# Altium schematic sheet 03-MOTEUR_BUZ_LED.SchDoc(03-MOTEUR_BUZ_LED)
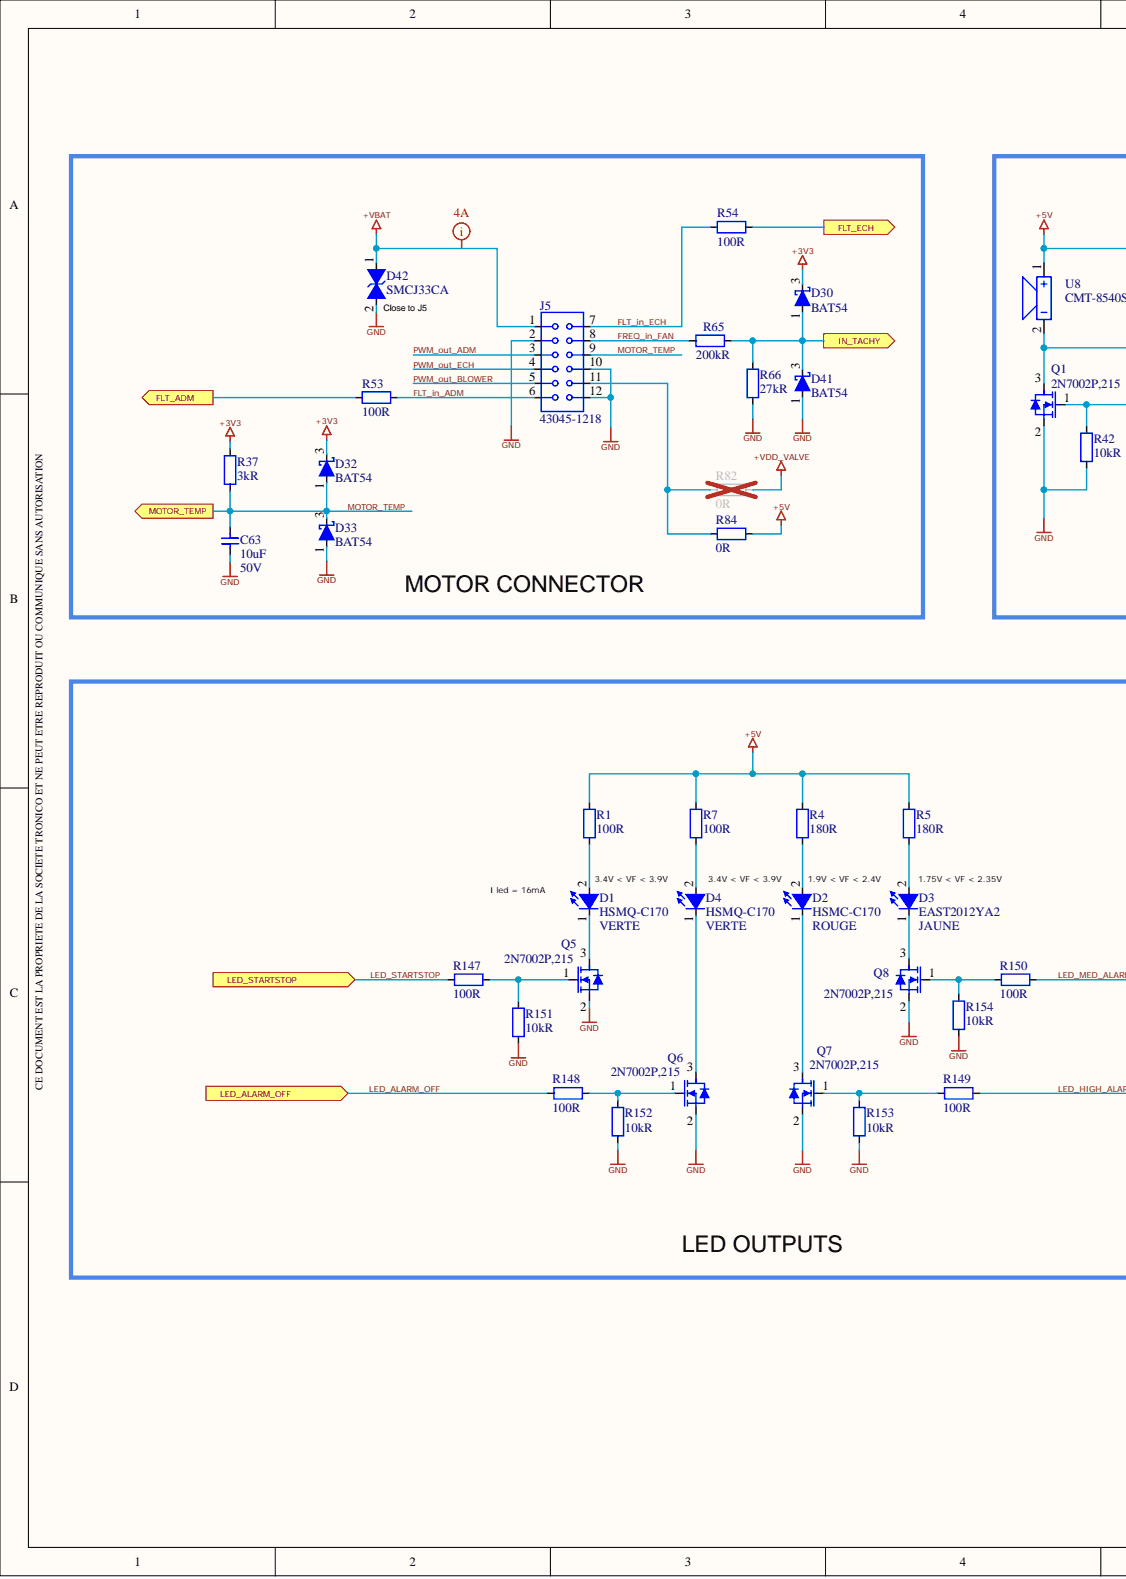
[diagram p0: 03-MOTEUR_BUZ_LED.SchDoc, left]
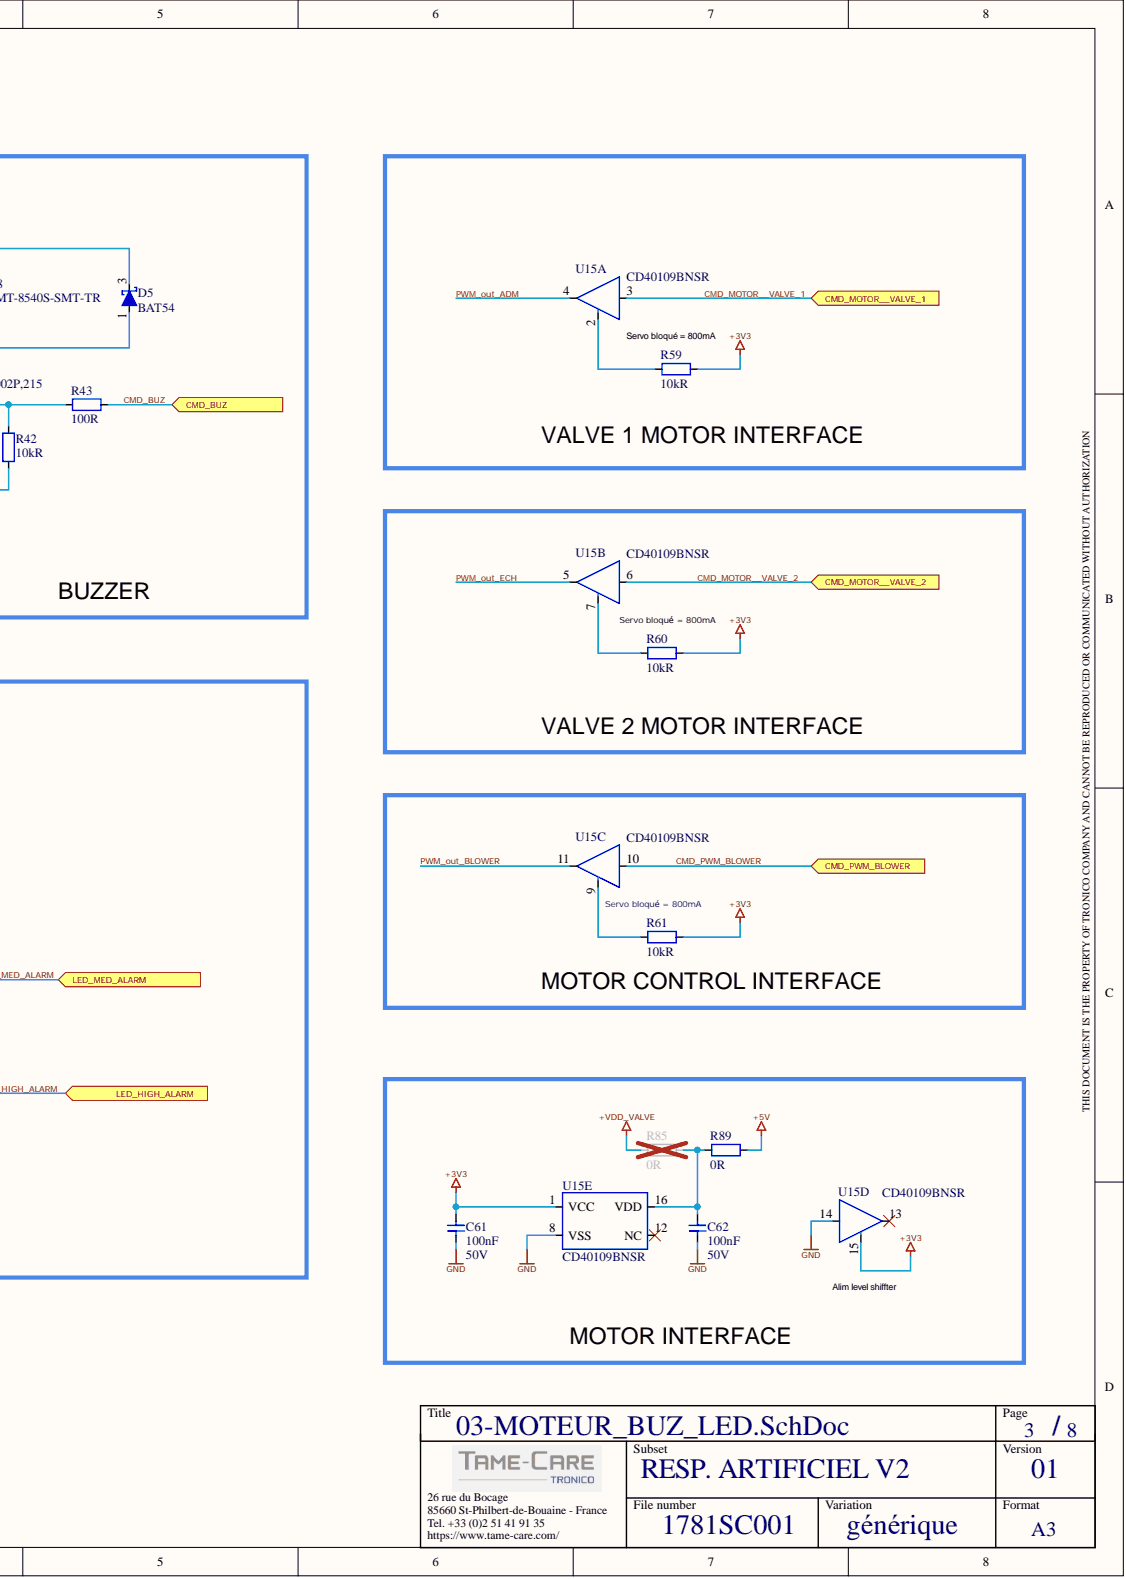
[diagram p1: 03-MOTEUR_BUZ_LED.SchDoc, right]

## Parts
C61  100nF  Parameter 1: 50V  [CAPC_0603X094_T]
C62  100nF  Parameter 1: 50V  [CAPC_0603X094_T]
C63  10uF  Parameter 1: 50V  [CAPC_1206X160_T]
D1  HSMQ-C170  Parameter 1: VERTE  CHAMP7: 3.4V  [LEDC_0805X080_GREEN_T]
D2  HSMC-C170  Parameter 1: ROUGE  [LEDC_0805X080_RED_T]
D3  EAST2012YA2  Parameter 1: JAUNE  [LEDC_0805X100_YELLOW_T]
D4  HSMQ-C170  Parameter 1: VERTE  CHAMP7: 3.4V  [LEDC_0805X080_GREEN_T]
D5  BAT54  [SOT23_T]
D30  BAT54  [SOT23_T]
D32  BAT54  [SOT23_T]
D33  BAT54  [SOT23_T]
D41  BAT54  [SOT23_T]
D42  SMCJ33CA  [DIOC_SMC_DO-214AB_BIDIR_B]
J5  43045-1218  [CNT_MOLEX_43045-1218_B]
Q1  2N7002P,215  [SOT23_T]
Q5  2N7002P,215  [SOT23_T]
Q6  2N7002P,215  [SOT23_T]
Q7  2N7002P,215  [SOT23_T]
Q8  2N7002P,215  [SOT23_T]
R1  100R  [RESC_0603X045_T]
R4  180R  [RESC_0603X045_T]
R5  180R  [RESC_0603X045_T]
R7  100R  [RESC_0603X045_T]
R37  3kR  [RESC_0603X045_T]
R42  10kR  [RESC_0603X045_T]
R43  100R  [RESC_0603X045_T]
R53  100R  [RESC_0603X045_T]
R54  100R  [RESC_0603X045_T]
R59  10kR  [RESC_0603X045_T]
R60  10kR  [RESC_0603X045_T]
R61  10kR  [RESC_0603X045_T]
R65  200kR  [RESC_0603X045_T]
R66  27kR  [RESC_0603X045_T]
R82  0R  [RESC_1206X055_T]
R84  0R  [RESC_1206X055_T]
R85  0R  [RESC_1206X055_T]
R89  0R  [RESC_1206X055_T]
R147  100R  [RESC_0603X045_T]
R148  100R  [RESC_0603X045_T]
R149  100R  [RESC_0603X045_T]
R150  100R  [RESC_0603X045_T]
R151  10kR  [RESC_0603X045_T]
R152  10kR  [RESC_0603X045_T]
R153  10kR  [RESC_0603X045_T]
R154  10kR  [RESC_0603X045_T]
U8  CMT-8540S-SMT-TR  [BUZZER_CUI-CMT-8540S-SMT-TR_B]
U15  CD40109BNSR  [SO16_10.2x5.3_1.27]  (parts A,B,C,D,E)

## Ports
CMD_BUZ, CMD_MOTOR__VALVE_1, CMD_MOTOR__VALVE_2, CMD_PWM_BLOWER, FLT_ADM, FLT_ECH, IN_TACHY, LED_ALARM_OFF, LED_HIGH_ALARM, LED_MED_ALARM, LED_STARTSTOP, MOTOR_TEMP

## Nets
+3V3: C61.2 D30.3 D32.3 R37.1 R59.2 R60.2 R61.2 U15.1(VCC) U15.15
+5V: D5.3 R1.1 R4.1 R5.1 R7.1 R84.1 R89.1 U8.1
+VBAT: D42.1 J5.1
+VDD_VALVE: R82.1 R85.2
GND: C61.1 C62.1 C63.1 D33.1 D41.1 D42.2 J5.2 J5.10 J5.12 Q1.2 Q5.2 Q6.2 Q7.2 Q8.2 R42.2 R66.2 R151.2 R152.2 R153.2 R154.2 U15.8(VSS) U15.14
CMD_BUZ: R43.1 ; port CMD_BUZ
CMD_MOTOR__VALVE_1: U15.3 ; port CMD_MOTOR__VALVE_1
CMD_MOTOR__VALVE_2: U15.6 ; port CMD_MOTOR__VALVE_2
CMD_PWM_BLOWER: U15.10 ; port CMD_PWM_BLOWER
FLT_ADM: R53.2 ; port FLT_ADM
FLT_ECH: R54.1 ; port FLT_ECH
FLT_in_ADM: J5.6 R53.1
FLT_in_ECH: J5.7 R54.2
FREQ_in_FAN: J5.8 R65.2
IN_TACHY: D30.1 D41.3 R65.1 R66.1 ; port IN_TACHY
LED_ALARM_OFF: R148.2 ; port LED_ALARM_OFF
LED_HIGH_ALARM: R149.1 ; port LED_HIGH_ALARM
LED_MED_ALARM: R150.1 ; port LED_MED_ALARM
LED_STARTSTOP: R147.2 ; port LED_STARTSTOP
MOTOR_TEMP: C63.2 D32.1 D33.3 J5.9 R37.2 ; port MOTOR_TEMP
PWM_out_ADM: J5.3 U15.4
PWM_out_BLOWER: J5.5 U15.11
PWM_out_ECH: J5.4 U15.5
NetC62_2: C62.2 R85.1 R89.2 U15.16(VDD)
NetD1_1: D1.1 Q5.3
NetD1_2: D1.2 R1.2
NetD2_1: D2.1 Q7.3
NetD2_2: D2.2 R4.2
NetD3_1: D3.1 Q8.3
NetD3_2: D3.2 R5.2
NetD4_1: D4.1 Q6.3
NetD4_2: D4.2 R7.2
NetD5_1: D5.1 Q1.3 U8.2
NetJ5_11: J5.11 R82.2 R84.2
NetQ1_1: Q1.1 R42.1 R43.2
NetQ5_1: Q5.1 R147.1 R151.1
NetQ6_1: Q6.1 R148.1 R152.1
NetQ7_1: Q7.1 R149.2 R153.1
NetQ8_1: Q8.1 R150.2 R154.1
NetR59_1: R59.1 U15.2
NetR60_1: R60.1 U15.7
NetR61_1: R61.1 U15.9

Not connected: U15 12(NC) 13

## Library data of the parts
C61 C62 = 23U00036: COND_CERAM CMS 0603 X7R 100nF 50V 10%; REV_NOTE: Maj Champs Techniques; REV_AUTHOR: nlelievre; REF_FAB: 06035C104KAT2A; LEVEL: 1; FAMILLE: CAPACITIFS; FAB: AVX; Database Table Name: v_bt_all; CHAMP9: -55°C/+125°C; CHAMP2: 0603; SS_FAMILLE: COND_CERAM; Simulation: CAP; Signal Integrity: Cap; REV_DATE: 16/10/2019 13:20:57; Library Name: SVNDatabase_BaseTechnique.SVNDbLib; ID_RnD: 23U00036; HelpURL: http://Bt_rnd/ds/02_capacitif/AVX/AVX_cx7r.pdf; ComponentLink2Description: Manufacturer Website; ComponentLink1Description: Manufacturer Datasheet; Component Kind: Standard; CHAMP7: 10%; CHAMP6: 50V; CHAMP5: 100nF; CHAMP3: X7R; CHAMP1: CMS; ACTIF: ACTIF
C63 = 23U00488: COND_CERAM CMS 1206 X5R 10uF 50V 10%; REV_NOTE: Migration vers t_bt; REV_AUTHOR: lclavier; REF_FAB: GRM31CR61H106KA12L; LEVEL: 1; FAMILLE: CAPACITIFS; FAB: MURATA; Database Table Name: v_bt_all; CHAMP9: -55°C/+85°C; CHAMP2: 1206; SS_FAMILLE: COND_CERAM; Simulation: CAP; Signal Integrity: Cap; REV_DATE: 14/04/2020 16:23:14; ID_RnD: 23U00488; HelpURL: http://bt_rnd/ds/02_capacitif/murata/GRM31CR61H106KA12L.pdf; ComponentLink1URL: https://www.murata.com/en-us/products/productdetail?partno=GRM31CR61H106KA12%23; Component Kind: Standard; CHAMP7: 10%; CHAMP6: 50V; CHAMP5: 10uF; CHAMP3: X5R; CHAMP1: CMS; ACTIF: ACTIF; Library Name: SVNDatabase_BaseTechnique.SVNDbLib
D1 D4 = E1U00030: DIODE_LED VERTE CMS 0805 520 78mW 3.4V 20mA; REV_NOTE: Migration vers t_bt; REV_AUTHOR: lclavier; LEVEL: 1; FAMILLE: OPTOELECTRONIQUE; FAB: AVAGO; Database Table Name: v_bt_all; CHAMP10: -40°C/+85°C; CHAMP5: 520; CHAMP4: 0805; SS_FAMILLE: DIODE_LED; REV_DATE: 02/05/2020 13:21:23; REF_FAB: HSMQ-C170; ID_RnD: E1U00030; HelpURL: http://bt_rnd/ds/15_opto/AVAGO/HSMQ-C1xx-HSMR-C1xx.pdf; ComponentLink2Description: Manufacturer Website; ComponentLink1Description: Manufacturer Datasheet; Component Kind: Standard; CHAMP9: -40°C/+85°C; CHAMP8: 20mA; CHAMP6: 78mW; CHAMP3: CMS; CHAMP1: VERTE; ACTIF: ACTIF; Library Name: SVNDatabase_BaseTechnique.SVNDbLib
D2 = E1U00031: DIODE_LED ROUGE CMS 0805 637 60mW 2.4V 25mA; REV_NOTE: Migration vers t_bt; REV_AUTHOR: lclavier; LEVEL: 1; FAMILLE: OPTOELECTRONIQUE; FAB: AVAGO; Database Table Name: v_bt_all; CHAMP10: -40°C/+85°C; CHAMP5: 637; CHAMP4: 0805; SS_FAMILLE: DIODE_LED; REV_DATE: 02/05/2020 13:21:25; REF_FAB: HSMC-C170; ID_RnD: E1U00031; HelpURL: http://bt_rnd/ds/15_opto/AVAGO/HSMx-C110-C170-C190-C191-C150.pdf; ComponentLink2Description: Manufacturer Website; ComponentLink1Description: Manufacturer Datasheet; Component Kind: Standard; CHAMP9: -40°C/+85°C; CHAMP8: 25mA; CHAMP7: 2.4V; CHAMP6: 60mW; CHAMP3: CMS; CHAMP1: ROUGE; ACTIF: ACTIF; Library Name: SVNDatabase_BaseTechnique.SVNDbLib
D3 = E1U00032: DIODE_LED JAUNE CMS 0805 591 85mcd 2.05V 20mA; REV_NOTE: Migration vers t_bt; REV_AUTHOR: lclavier; LEVEL: 1; FAMILLE: OPTOELECTRONIQUE; FAB: Everlight Electronics; Database Table Name: v_bt_all; CHAMP10: -40°C/+90°C; CHAMP9: -40°C/+85°C; CHAMP5: 591; CHAMP4: 0805; SS_FAMILLE: DIODE_LED; REV_DATE: 02/05/2020 13:21:28; REF_FAB: EAST2012YA2; ID_RnD: E1U00032; HelpURL: http://bt_rnd/ds/15_opto/EVERLIGHT/EAST2012YA2-708101.pdf; ComponentLink2Description: Manufacturer Website; ComponentLink1Description: Manufacturer Datasheet; Component Kind: Standard; CHAMP8: 20mA; CHAMP7: 2.05V; CHAMP6: 85mcd; CHAMP3: CMS; CHAMP1: JAUNE; ACTIF: ACTIF; Library Name: SVNDatabase_BaseTechnique.SVNDbLib
D5 D30 D32 D33 D41 = 33U00013: DIODE_DE_SIGNAL CMS SOT23  30V 200mA -55°C/+150°C -55°C/+150°C; REV_NOTE: MàJ footprint_path et footprint_ref; REV_AUTHOR: llannuzel; QUALIF: None; LEVEL: 1; FAMILLE: DIODES; FAB: FAIRCHILD; Database Table Name: v_bt_all; SS_FAMILLE: DIODE_DE_SIGNAL; Simulation: SKYDIODE; Signal Integrity: D Schottky; REV_DATE: 13/12/2019 10:03:18; REF_FAB: BAT54; ID_RnD: 33U00013; HelpURL: http://bt_rnd/ds/03_diode/FAIRCHILD/BAT54_x.pdf; ComponentLink2Description: Manufacturer Website; ComponentLink1Description: Manufacturer Datasheet; Component Kind: Standard; CHAMP10: -55°C/+150°C; CHAMP9: -55°C/+150°C; CHAMP6: 200mA; CHAMP5: 30V; CHAMP2: SOT23; CHAMP1: CMS; ACTIF: ACTIF; Library Name: SVNDatabase_BaseTechnique.SVNDbLib
D42 = 36U00007: DIODE_TRANSIL CMS DO214AB  BI 33V 1500W; REV_NOTE: MaJ Champs URL; REV_AUTHOR: llannuzel; LEVEL: 1; FAMILLE: DIODES; FAB: FAIRCHILD; Database Table Name: v_bt_all; CHAMP10: -55°C/+150°C; CHAMP2: DO-214AB; SS_FAMILLE: DIODE_TRANSIL; REV_DATE: 02/05/2020 17:44:04; REF_FAB: SMCJ33CA; ID_RnD: 36U00007; HelpURL: http://bt_rnd/ds/03_diode/FAIRCHILD/SMCJ_serie.pdf; ComponentLink2Description: None; ComponentLink1Description: None; Component Kind: Standard; CHAMP9: -55°C/+150°C; CHAMP6: 1500W; CHAMP5: 33V; CHAMP4: BI; CHAMP1: CMS; ACTIF: ACTIF; Library Name: SVNDatabase_BaseTechnique.SVNDbLib
J5 = A7U00305: CONNECT MICRO-FIT CMS 2x6PTS EMBASE RECT MALE DROIT; REV_NOTE: MaJ Champs Techniques; REV_AUTHOR: lclavier; QUALIF: None; LEVEL: 1; FAMILLE: CONNECTIQUE; FAB: MOLEX; Database Table Name: v_bt_all; CHAMP10: -40°C/+105°C; SS_FAMILLE: CONNECT; REV_DATE: 29/04/2020 19:11:28; REF_FAB: 43045-1218; Library Name: SVNDatabase_BaseTechnique.SVNDbLib; ID_RnD: A7U00305; HelpURL: http://bt_rnd/ds/10_connectique/MOLEX/0430451218_PCB_HEADERS.pdf; ComponentLink2Description: Manufacturer Website; ComponentLink1Description: Manufacturer Datasheet; Component Kind: Standard; CHAMP9: -40°C/+105°C; CHAMP8: DROIT; CHAMP7: MALE; CHAMP6: RECT; CHAMP5: EMBASE; CHAMP4: 2x6PTS; CHAMP3: CMS; CHAMP1: MICRO-FIT; ACTIF: ACTIF
Q1 Q5-Q8 = 92U00012: TRANSISTOR_MOS CMS SOT23 CANAL N 60V 0.36A -55°C/+150°C; Valide / Non Valide: Ok; REV_NOTE: Maj Library Ref; REV_AUTHOR: jcaille; LEVEL: 1; FAMILLE: TRANSISTORS; FAB: NXP; Database Table Name: v_bt_all; CHAMP10: -65°C/+150°C; CHAMP5: CANAL N; SS_FAMILLE: TRANSISTOR_MOS; REV_DATE: 22/01/2020 14:26:53; REF_FAB: 2N7002P,215; ID_RnD: 92U00012; HelpURL: http://bt_rnd/ds/09_transistor/NXP/NXP_2N7002P.pdf; ComponentLink2Description: Manufacturer Website; ComponentLink1Description: Manufacturer Datasheet; Component Kind: Standard; CHAMP9: -55°C/+150°C; CHAMP7: 0.36A; CHAMP6: 60V; CHAMP2: SOT23; CHAMP1: CMS; ACTIF: ACTIF; Library Name: SVNDatabase_BaseTechnique.SVNDbLib
R1 R7 R43 R53 R54 R147-R150 = 11U00071: REST CMS 0603 100PPM 100R 1/10W 1% -55°C/+155°C; Valide / Non Valide: OK; REV_NOTE: MaJ description; REV_AUTHOR: jrenvoise; REF_FAB: RC0603FR-07100RL; LEVEL: 1; FAMILLE: RESISTIFS; FAB: YAGEO; Database Table Name: v_bt_all; CHAMP2: 0603; SS_FAMILLE: REST; Simulation: RESISTOR; Signal Integrity: Res; REV_DATE: 12/09/2018 10:54:26; ID_RnD: 11U00071; HelpURL: http://bt_rnd/ds/01_resistif/yageo/RC-L_Series.pdf; ComponentLink2Description: Manufacturer Website; ComponentLink1Description: Manufacturer Datasheet; Component Kind: Standard; CHAMP9: -55°C/+155°C; CHAMP7: 1%; CHAMP6: 1/10W; CHAMP5: 100R; CHAMP3: 100PPM; CHAMP1: CMS; ACTIF: ACTIF; Library Name: SVNDatabase_BaseTechnique.SVNDbLib
R4 R5 = 11U01011: REST CMS 0603 200PPM 180R 1/10W 1% 75V; Valide / Non Valide: OK; REV_NOTE: Migration vers t_bt; REV_AUTHOR: jcaille; REF_FAB: CRCW0603180RJNEA; LEVEL: 1; FAMILLE: RESISTIFS; FAB: VISHAY; Database Table Name: v_bt_all; CHAMP9: -55°C/+155°C; CHAMP2: 0603; SS_FAMILLE: REST; Simulation: RESISTOR; Signal Integrity: Res; REV_DATE: 17/04/2020 11:05:26; ID_RnD: 11U01011; HelpURL: http://bt_rnd/ds/01_resistif/Vishay/dcrcwe3.pdf; ComponentLink2Description: Manufacturer Website; ComponentLink1Description: Manufacturer Datasheet; Component Kind: Standard; CHAMP8: 75V; CHAMP7: 1%; CHAMP6: 1/10W; CHAMP5: 180R; CHAMP3: 200PPM; CHAMP1: CMS; ACTIF: ACTIF; Library Name: SVNDatabase_BaseTechnique.SVNDbLib
R37 = 11U00977: REST CMS 0603 100PPM 3kR 1/10W 1% -55°C/+155°C; Valide / Non Valide: OK; REV_NOTE: MAJ DESCRIPTION; REV_AUTHOR: lclavier; REF_FAB: RC0603FR-073KL; QUALIF: None; LEVEL: 1; FAMILLE: RESISTIFS; FAB: YAGEO; Database Table Name: v_bt_all; CHAMP2: 0603; SS_FAMILLE: REST; Simulation: RESISTOR; Signal Integrity: Res; REV_DATE: 07/02/2020 15:40:42; ID_RnD: 11U00977; HelpURL: http://bt_rnd/ds/01_resistif/yageo/RC-L_Series.pdf; ComponentLink2Description: Manufacturer Website; ComponentLink1Description: Manufacturer Datasheet; Component Kind: Standard; CHAMP9: -55°C/+155°C; CHAMP7: 1%; CHAMP6: 1/10W; CHAMP5: 3kR; CHAMP3: 100PPM; CHAMP1: CMS; ACTIF: ACTIF; Library Name: SVNDatabase_BaseTechnique.SVNDbLib
R42 R59-R61 R151-R154 = 11U00108: REST CMS 0603 100PPM 10kR 1/10W 1% -55°C/+155°C; Valide / Non Valide: OK; REV_NOTE: MaJ description; REV_AUTHOR: jrenvoise; REF_FAB: RC0603FR-0710KL; LEVEL: 1; FAMILLE: RESISTIFS; FAB: YAGEO; Database Table Name: v_bt_all; CHAMP2: 0603; SS_FAMILLE: REST; Simulation: RESISTOR; Signal Integrity: Res; REV_DATE: 12/09/2018 10:54:26; ID_RnD: 11U00108; HelpURL: http://bt_rnd/ds/01_resistif/yageo/RC-L_Series.pdf; ComponentLink2Description: Manufacturer Website; ComponentLink1Description: Manufacturer Datasheet; Component Kind: Standard; CHAMP9: -55°C/+155°C; CHAMP7: 1%; CHAMP6: 1/10W; CHAMP5: 10kR; CHAMP3: 100PPM; CHAMP1: CMS; ACTIF: ACTIF; Library Name: SVNDatabase_BaseTechnique.SVNDbLib
R65 = 11U01021: REST CMS 0603 100PPM 200kR 1/10W 1% 75V; Valide / Non Valide: OK; REV_NOTE: Migration vers t_bt; REV_AUTHOR: llannuzel; REF_FAB: RC0603FR-07200KL; LEVEL: 1; FAMILLE: RESISTIFS; FAB: YAGEO; Database Table Name: v_bt_all; CHAMP9: -55°C/+155°C; CHAMP2: 0603; SS_FAMILLE: REST; Simulation: RESISTOR; Signal Integrity: Res; REV_DATE: 02/05/2020 16:28:40; ID_RnD: 11U01021; HelpURL: http://bt_rnd/ds/01_resistif/yageo/PYu-RC_Group_51_RoHS_L_10.pdf; Component Kind: Standard; CHAMP8: 75V; CHAMP7: 1%; CHAMP6: 1/10W; CHAMP5: 200kR; CHAMP3: 100PPM; CHAMP1: CMS; ACTIF: ACTIF; Library Name: SVNDatabase_BaseTechnique.SVNDbLib
R66 = 11U00176: CMS 0603 100PPM  27kR 1/10W 1%  -55°C/+155°C; Valide / Non Valide: OK; SS_FAMILLE: REST; REV_NOTE: Migration vers t_bt; REV_AUTHOR: jennifer; REF_FAB: RC0603FR-0727KL; LEVEL: 1; FAMILLE: RESISTIFS; FAB: YAGEO; Database Table Name: v_bt_all; CHAMP2: 0603; Simulation: RESISTOR; Signal Integrity: Res; REV_DATE: 27/07/2018 10:30:43; ID_RnD: 11U00176; HelpURL: http://bt_rnd/ds/01_resistif/yageo/RC-L_Series.pdf; ComponentLink2Description: Manufacturer Website; ComponentLink1Description: Manufacturer Datasheet; Component Kind: Standard; CHAMP9: -55°C/+155°C; CHAMP7: 1%; CHAMP6: 1/10W; CHAMP5: 27kR; CHAMP3: 100PPM; CHAMP1: CMS; ACTIF: ACTIF; Library Name: SVNDatabase_BaseTechnique.SVNDbLib
R82 R84 R85 R89 = 11U00878: REST CMS 1206 0R 1/4W 20mR 3.5A; Valide / Non Valide: OK; REV_NOTE: Migration vers t_bt; REV_AUTHOR: jcaille; REF_FAB: RCA12060000ZSEA; LEVEL: 1; FAMILLE: RESISTIFS; FAB: VISHAY; Database Table Name: v_bt_all; CHAMP9: -55°C/+155°C; CHAMP2: 1206; SS_FAMILLE: REST; Simulation: RESISTOR; Signal Integrity: Res; REV_DATE: 25/11/2019 10:49:44; ID_RnD: 11U00878; HelpURL: http://bt_rnd/ds/01_resistif/Vishay/RCAE3.pdf; ComponentLink2Description: Manufacturer Website; ComponentLink1Description: Manufacturer Datasheet; Component Kind: Standard; CHAMP8: 3.5A; CHAMP7: 20mR; CHAMP6: 1/4W; CHAMP5: 0R; CHAMP1: CMS; ACTIF: ACTIF; Library Name: SVNDatabase_BaseTechnique.SVNDbLib
U8 = 74U00004: BUZZER CMS 8.5x8.5x4 TRANSD 4kHz +1V/+6V 100dBA; REV_NOTE: Migration vers t_bt; REV_AUTHOR: lclavier; LEVEL: 1; FAMILLE: PIEZO; FAB: CUI INC; Database Table Name: v_bt_all; CHAMP10: -40°C/+85°C; CHAMP9: -20°C/+70°C; SS_FAMILLE: BUZZER; REV_DATE: 20/04/2020 18:12:13; REF_FAB: CMT-8540S-SMT-TR; ID_RnD: 74U00004; HelpURL: http://bt_rnd/ds/07_piezo/CUI/cmt-8540s-smt-tr.pdf; ComponentLink2Description: Manufacturer Website; ComponentLink1Description: Manufacturer Datasheet; Component Kind: Standard; CHAMP8: 100dBA; CHAMP7: +1V/+6V; CHAMP6: 4kHz; CHAMP5: TRANSD; CHAMP2: 8.5x8.5x4; CHAMP1: CMS; ACTIF: ACTIF; Library Name: SVNDatabase_BaseTechnique.SVNDbLib
U15 = 64U00036: CI_MEMOIRE CMS SO16 Voltage level Shifter +3V/+18V; REV_NOTE: Migration vers t_bt; REV_AUTHOR: lclavier; LEVEL: 1; FAMILLE: CIRCUITS_INTEGRES; FAB: TEXAS INSTRUMENTS; Database Table Name: v_bt_all; CHAMP10: -65°C/+150°C; CHAMP9: -55°C/+125°C; SS_FAMILLE: CI_MEMOIRE; REV_DATE: 02/05/2020 13:21:30; REF_FAB: CD40109BNSR; ID_RnD: 64U00036; HelpURL: http://bt_rnd/ds/06_ci/TI/cd40109b.pdf; ComponentLink2Description: Manufacturer Website; ComponentLink1Description: Manufacturer Datasheet; Component Kind: Standard; CHAMP6: +3V/+18V; CHAMP5: Voltage level Shifter; CHAMP2: SO16; CHAMP1: CMS; ACTIF: ACTIF; Library Name: SVNDatabase_BaseTechnique.SVNDbLib
